# Altium schematic sheet 电源路径选择.SchDoc(电源路径选择)
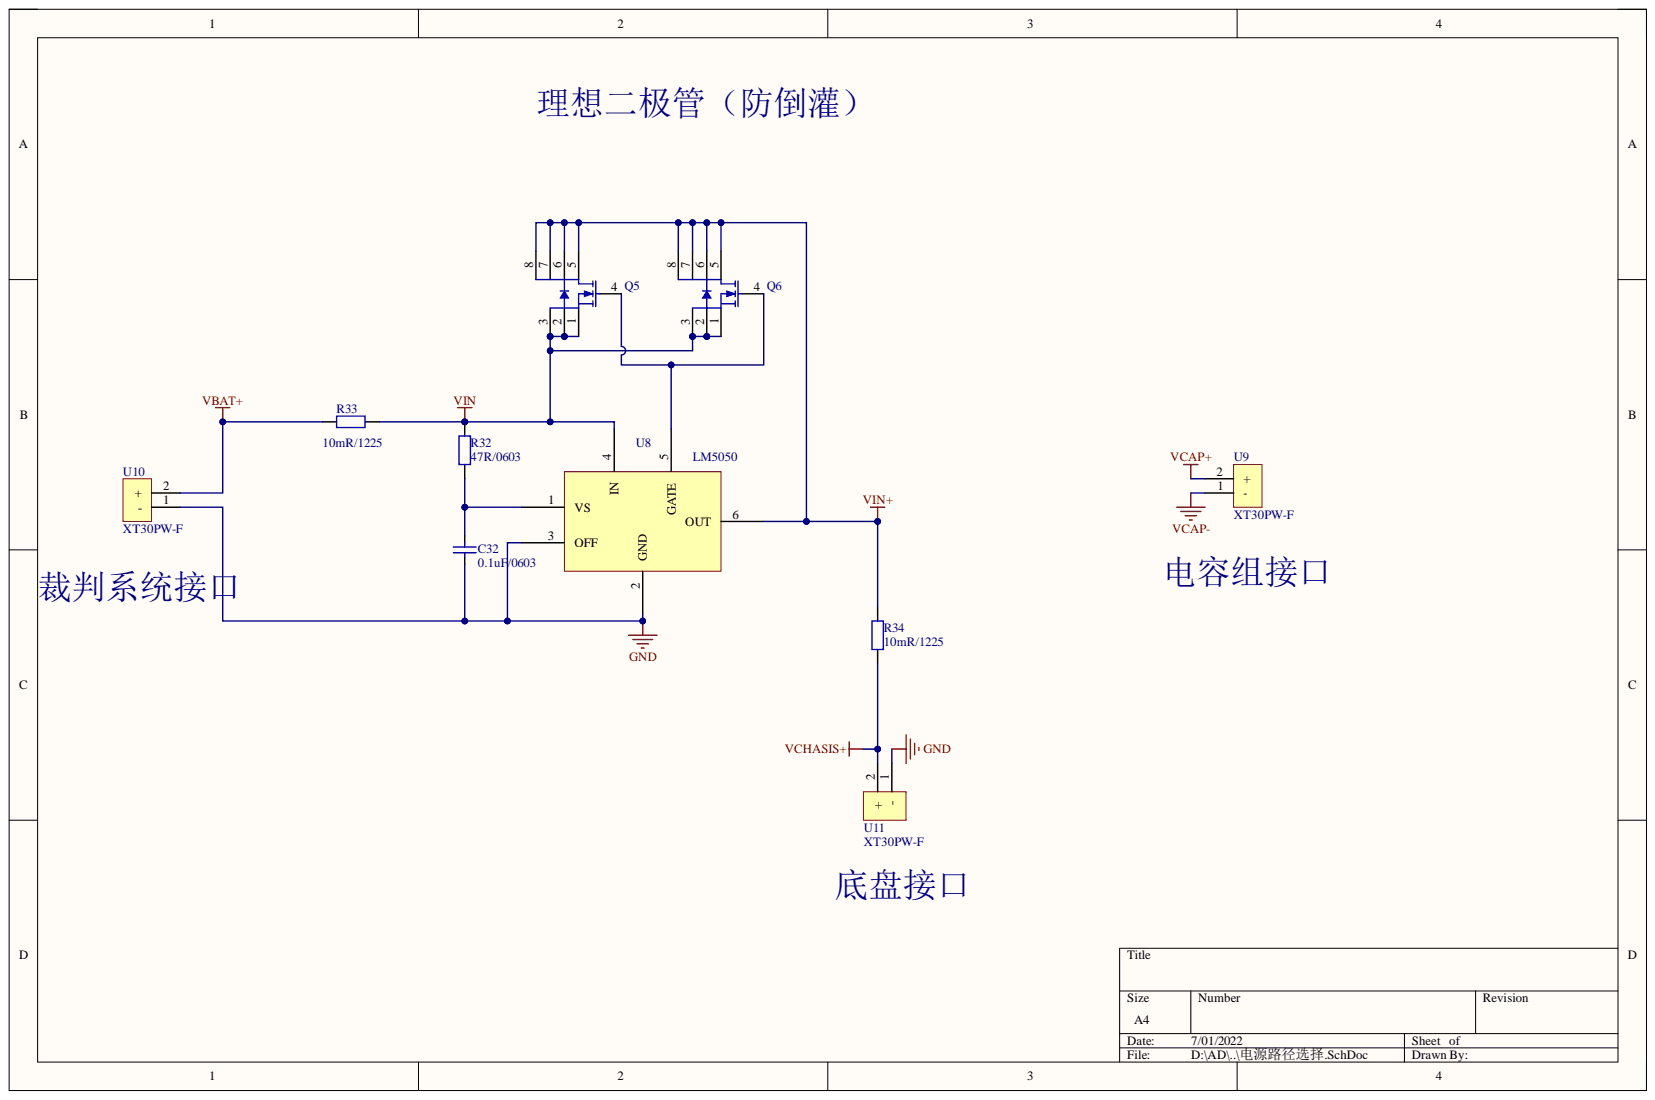
[diagram p0: 电源路径选择.SchDoc]

## Parts
C32  0.1uF  value 0.1uF/0603  [CAP_0603]
Q5  BSC030N08NS5  [TDSON-8]  (value not drawn)
Q6  BSC030N08NS5  [TDSON-8]  (value not drawn)
R32  47R  value 47R/0603  [RES_0603]
R33  10mR  value 10mR/1225  [RES_1225]
R34  10mR  value 10mR/1225  [RES_1225]
U8  LM5050  [SOT23-6]
U9  XT30PW-F  [XT30PW-F]
U10  XT30PW-F  [XT30PW-F]
U11  XT30PW-F  [XT30PW-F]

## Nets
GND: C32.1 U8.2(GND) U8.3(OFF) U10.1 U11.1
VBAT+: R33.1 U10.2
VCAP+: U9.2
VCAP-: U9.1
VCHASIS+: R34.1 U11.2
VIN: Q5.1 Q5.2 Q5.3 Q6.1 Q6.2 Q6.3 R32.2 R33.2 U8.4(IN)
VIN+: Q5.5 Q5.6 Q5.7 Q5.8 Q6.5 Q6.6 Q6.7 Q6.8 R34.2 U8.6(OUT)
NetC32_2: C32.2 R32.1 U8.1(VS)
NetQ5_4: Q5.4 Q6.4 U8.5(GATE)

## Library data of the parts
C32 = Cap_0603: Capacitor; Component Kind: Standard; Library Name: LIN_Library .SchLib
Q5 Q6 = N_MOSFET: N-MOSFET; Component Kind: Standard; Library Name: LIN_Library .SchLib
R32 = Res_0805: Resistor; Component Kind: Standard; Library Name: LIN_Library .SchLib
R33 R34 = Res_0603: Resistor; Component Kind: Standard; Library Name: LIN_Library .SchLib
U8 = LM5050: High-Side OR-ing FET Controller; Component Kind: Standard; Library Name: LIN_Library .SchLib
U9-U11 = XT30PW-F: Component Kind: Standard; Description: XT30PW-F; Library Name: LIN_Library .SchLib
